# Altium schematic sheet WATCHDOG.SchDoc(WATCHDOG)
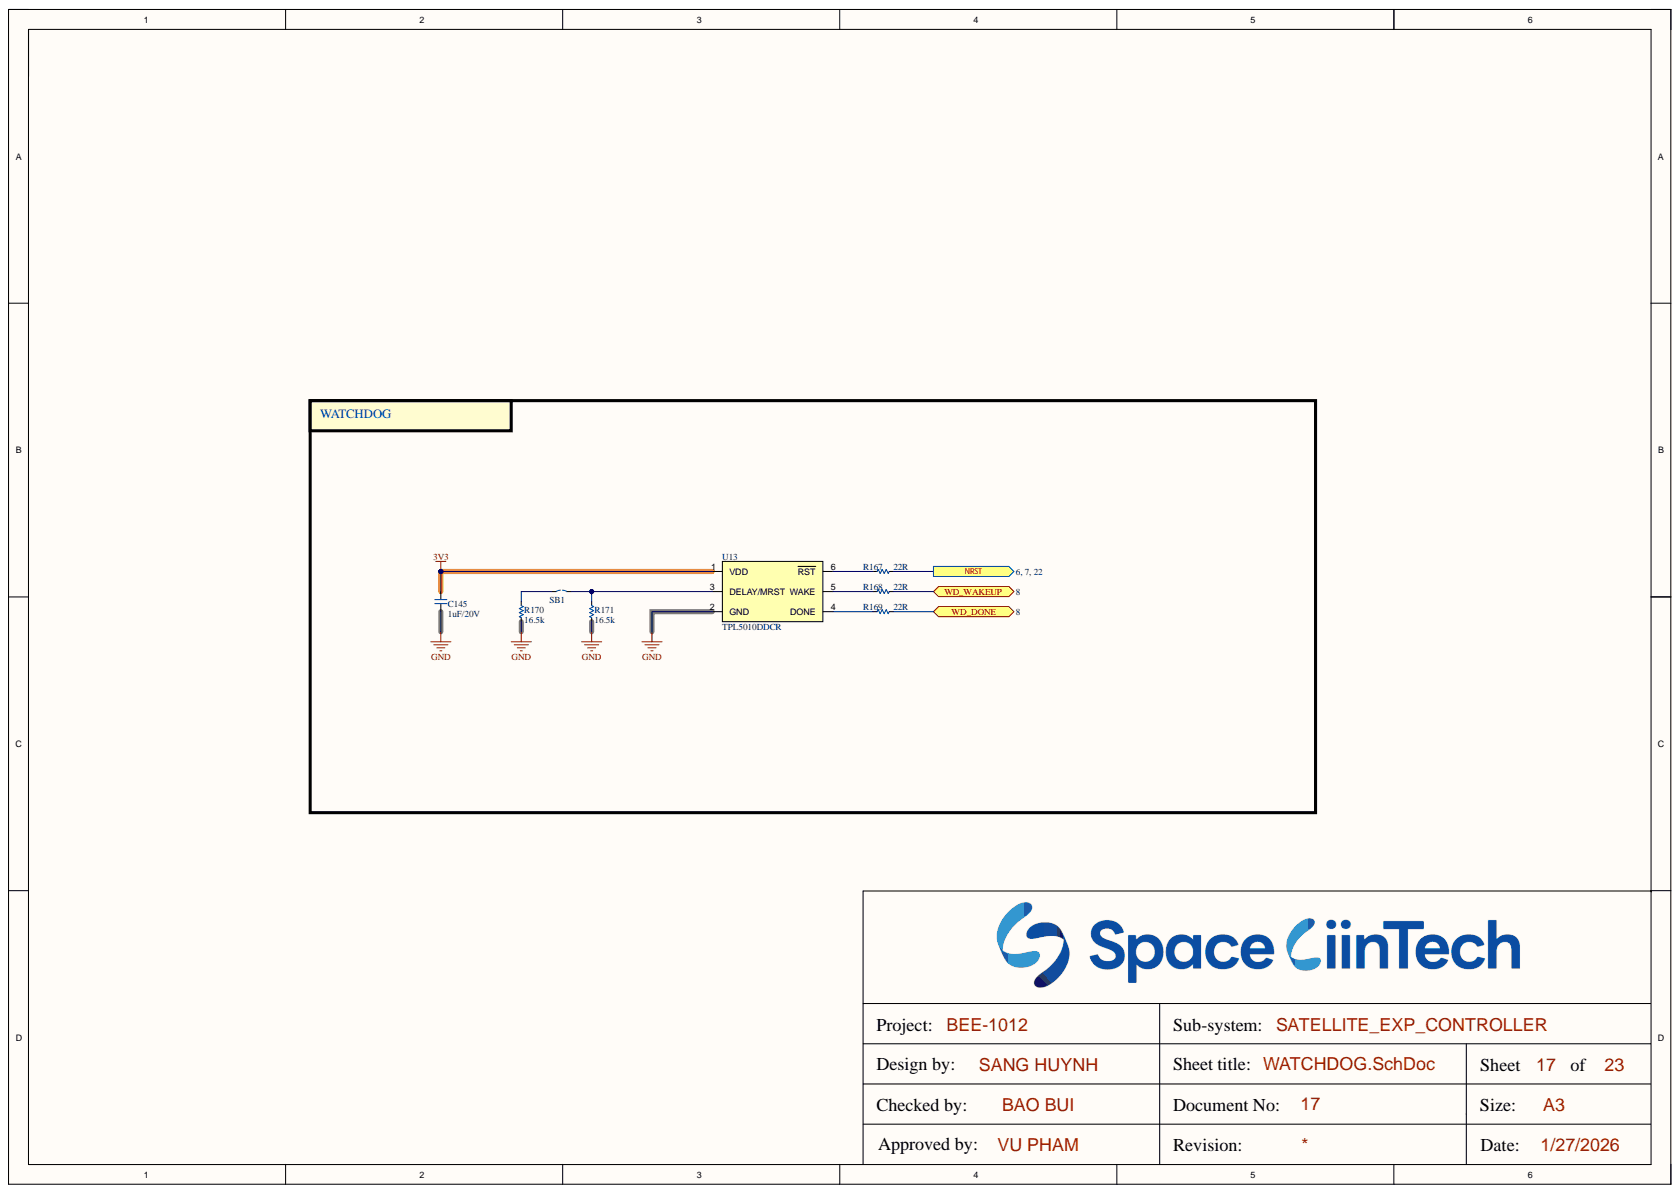
[diagram p0: WATCHDOG.SchDoc]

## Parts
C145  1uF/20V  [CAP_C_1005X50_L]
R167  22R  [RES_C_1005x30_L]
R168  22R  [RES_C_1005x30_L]
R169  22R  [RES_C_1005x30_L]
R170  16.5k  [RES_C_1005x30_L]
R171  16.5k  [RES_C_1005x30_L]
SB1  JUMPER  [JUMPER_1608]  (value not drawn)
U13  TPL5010DDCR  [TPL5010DDCR]

## Ports
NRST, WD_DONE, WD_WAKEUP

## Nets
3V3: C145.2 U13.1(VDD)
GND: C145.1 R170.2 R171.2 U13.2(GND)
NRST: R167.2 ; port NRST
WD_DONE: R169.2 ; port WD_DONE
WD_WAKEUP: R168.2 ; port WD_WAKEUP
NetR167_1: R167.1 U13.6(RST)
NetR168_1: R168.1 U13.5(WAKE)
NetR169_1: R169.1 U13.4(DONE)
NetR170_1: R170.1 SB1.1
NetR171_1: R171.1 SB1.2 U13.3(DELAY/MRST)

## Library data of the parts
C145 = _CAP_C_2: CAP CHIP; Component Kind: Standard; Library Name: IFBschelib.SchLib
R167-R171 = _RES_B_2: RES; Component Kind: Standard; Library Name: IFBschelib.SchLib
U13 = TPL5010DDCR: OSC PROG TIMER TSOT23-6; Component Kind: Standard; Library Name: IFBschelib.SchLib
SB1 = JUMPER: Component Kind: Standard; Library Name: IFBschelib.SchLib
